# Altium schematic sheet 7000-0133 Rev 02-TA mount PCA1.SchDoc("7000-0133 Rev 02-TA mount PCA1")
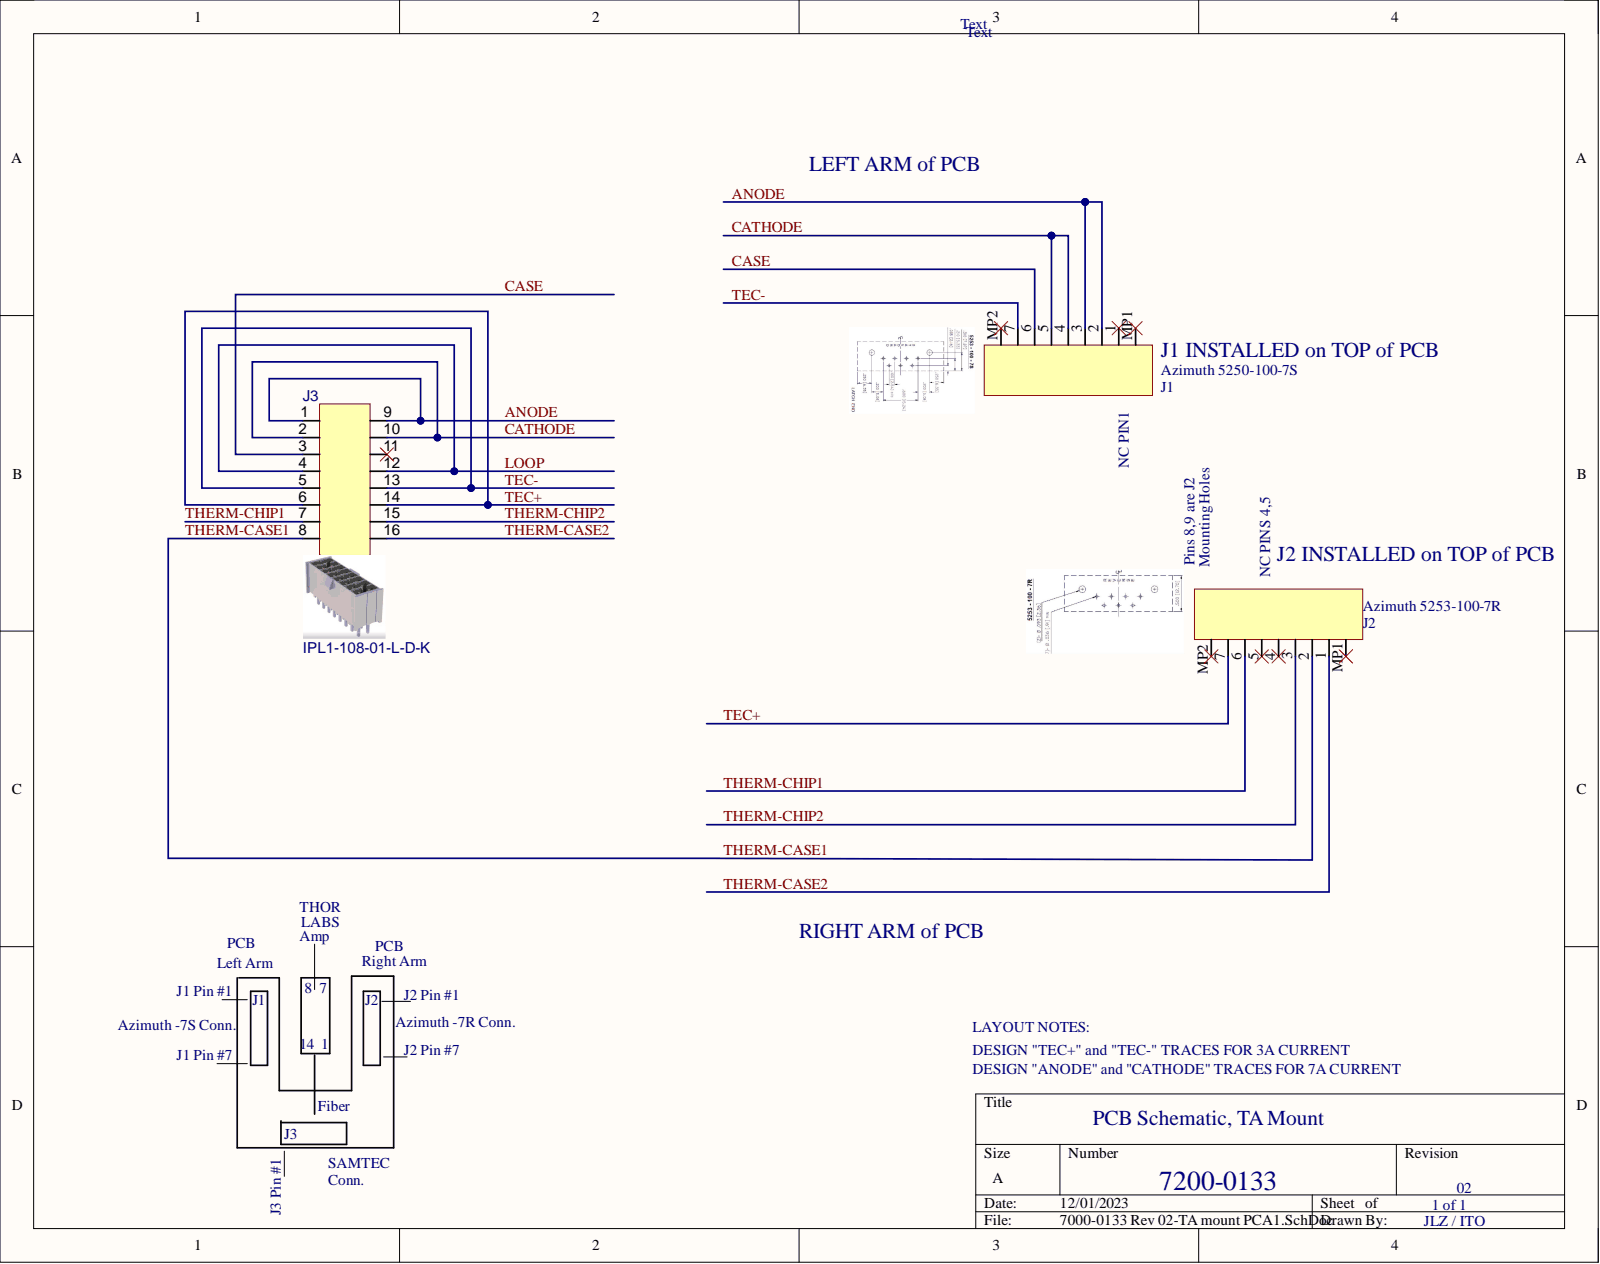
[diagram p0: 7000-0133 Rev 02-TA mount PCA1.SchDoc]

## Parts
J1  Azimuth 5250-100-7S  Manufacturer: Azimuth
J2  Azimuth 5253-100-7R  Manufacturer: Azimuth
J3  IPL1-108-01-L-D-K

## Nets
ANODE: J1.2 J1.3 J3.1 J3.9
CASE: J1.6 J3.3
CATHODE: J1.4 J1.5 J3.2 J3.10
LOOP: J3.4 J3.12
TEC+: J2.7 J3.6 J3.14
TEC-: J1.7 J3.5 J3.13
THERM-CASE1: J2.2 J3.8
THERM-CASE2: J2.1 J3.16
THERM-CHIP1: J2.6 J3.7
THERM-CHIP2: J2.3 J3.15

Not connected: J1 1 MP1 MP2; J2 4 5 MP1 MP2; J3 11

## Library data of the parts
J1 = Azimuth 5250-100-7S: Azimuth 5253-100-7-S Standard; Manufacturer Part Number: 5253-100-7S; Number of Positions: 7; Pitch: 2.54mm; Category: Electrical Component - Header; Component Kind: Standard; ComponentLink1Description: https://datasheet.ciiva.com/22665/shf-22665879.pdf?src-supplier=Element14; ComponentLink1URL: https://datasheet.ciiva.com/22665/shf-22665879.pdf?src-supplier=Element14; Library Name: 510 Connectors - Headers.SchLib; Number of Rows: 1; Orientation: Vertical; Series: KK254, 6373; Supplier 1: Digi-Key; Supplier Part Number 1: WM4204-ND; Author: SM
J2 = Azimuth 5253-100-7R: Azimuth 5253-100-7R reversed; Number of Positions: 7; Pitch: 2.54mm; Category: Electrical Component - Header; Component Kind: Standard; ComponentLink1Description: https://datasheet.ciiva.com/22665/shf-22665879.pdf?src-supplier=Element14; ComponentLink1URL: https://datasheet.ciiva.com/22665/shf-22665879.pdf?src-supplier=Element14; Library Name: 510 Connectors - Headers.SchLib; Manufacturer Part Number: 5253-100-7R; Number of Rows: 1; Orientation: Vertical; Supplier 1: Digi-Key; Supplier Part Number 1: WM4204-ND; Author: SM
J3 = IPL1-108-01-L-D-K: Connector Header Through Hole 16 position 0.100"; Manufacturer: Samtec; Current Rating: 2A; Number of Contacts: 16; Pitch: 2.54mm; Voltage Rating: 125V; Category: Electrical Component - Header; Colour: Black; Component Kind: Standard; Fastening Type: Keyed; Library Name: 510 Connectors - Headers.SchLib; Manufacturer Part Number: IPL1-108-01-L-D-K; Number of Positions: 16; Number of Rows: 2; Orientation: Straight; Series: Milli-Grid 87833; Supplier 1: Digi-Key; Supplier Part Number 1: SAM10585-ND; Author: SM
